# Altium schematic sheet DRIVER.SchDoc(DRIVER)
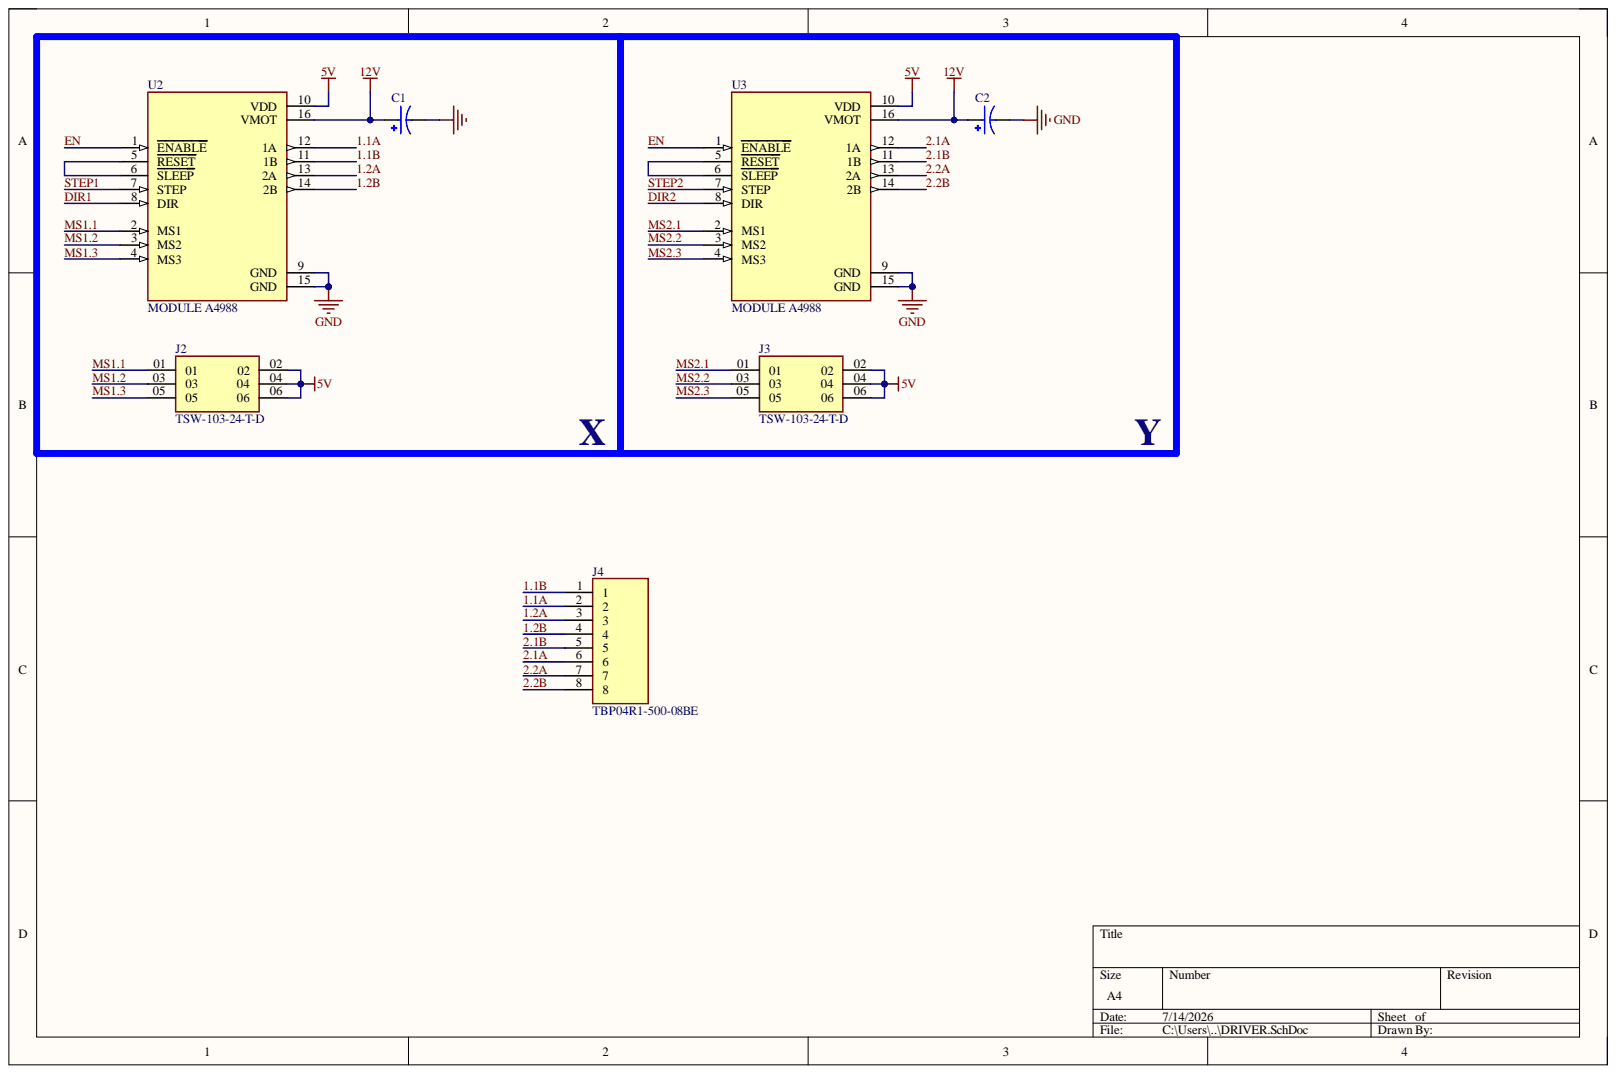
[diagram p0: DRIVER.SchDoc]

## Parts
C1  EEUFR1V101B  [EEU-FR1V101B]  (value not drawn)
C2  EEUFR1V101B  [EEU-FR1V101B]  (value not drawn)
J2  TSW-103-24-T-D  [TSW-103-24-T-D]
J3  TSW-103-24-T-D  [TSW-103-24-T-D]
J4  TBP04R1-500-08BE  [TBP04R1-500-08BE]
U2  MODULE A4988  [MODULE A4988]
U3  MODULE A4988  [MODULE A4988]

## Nets
5V: J2.02 J2.04 J2.06 J3.02 J3.04 J3.06 U2.10(VDD) U3.10(VDD)
12V: C1.P C2.P U2.16(VMOT) U3.16(VMOT)
GND: C1.N C2.N U2.9(GND) U2.15(GND) U3.9(GND) U3.15(GND)
1.1A: J4.2 U2.12(1A)
1.1B: J4.1 U2.11(1B)
1.2A: J4.3 U2.13(2A)
1.2B: J4.4 U2.14(2B)
2.1A: J4.6 U3.12(1A)
2.1B: J4.5 U3.11(1B)
2.2A: J4.7 U3.13(2A)
2.2B: J4.8 U3.14(2B)
DIR1: U2.8(DIR)
DIR2: U3.8(DIR)
EN: U2.1(ENABLE) U3.1(ENABLE)
MS1.1: J2.01 U2.2(MS1)
MS1.2: J2.03 U2.3(MS2)
MS1.3: J2.05 U2.4(MS3)
MS2.1: J3.01 U3.2(MS1)
MS2.2: J3.03 U3.3(MS2)
MS2.3: J3.05 U3.4(MS3)
STEP1: U2.7(STEP)
STEP2: U3.7(STEP)
NetU2_5: U2.5(RESET) U2.6(SLEEP)
NetU3_5: U3.5(RESET) U3.6(SLEEP)

## Library data of the parts
C1 C2 = EEUFR1V101B: AL LYTIC, RADIAL, 35VDC, 100UF; MF: Panasonic; Availability: In Stock; Check_prices: https://www.snapeda.com/parts/EEUFR1V101B/Panasonic/view-part/5790218/?ref=eda; Component Kind: Standard; Library Name: CAP.IntLib; MP: EEUFR1V101B; Package: RADIAL-2 Panasonic; Price: None; SnapEDA_Link: https://www.snapeda.com/parts/EEUFR1V101B/Panasonic/view-part/5790218/?ref=snap
J2 J3 = TSW-103-24-T-D: TSW - 2.54mm Samtec Pin Header 12.15mm Overall Pin Length (24) - Fast Stock(mcv); Availability: Unavailable; Component Kind: Standard; MP: TSW-103-24-T-D; Package: None; Price: None; Library Name: JUM.IntLib
J4 = TBP04R1-500-08BE: 2~24 Poles, Pluggable, Receptacle, Horizontal, 5.0 Pitch, Terminal Block Connector; MF: Same Sky; Check_prices: https://www.snapeda.com/parts/TBP04R1-500-08BE/Same+Sky/view-part/?ref=eda; Component Kind: Standard; Library Name: JACK.IntLib; MP: TBP04R1-500-08BE; Package: None; SnapEDA_Link: https://www.snapeda.com/parts/TBP04R1-500-08BE/Same+Sky/view-part/?ref=snap
U2 U3 = MODULE A4988: Stepper motor controler; IC: A4988; 1A; Uin mot: 8Ã·35V; MP: A4988_STEPPER_MOTOR_DRIVER_CARRIER; Availability: Unavailable; Component Kind: Standard; Library Name: MODULE.IntLib; Package: None; Price: None
